# Altium schematic sheet AMX_sensors30.SchDoc(AMX_sensors30)
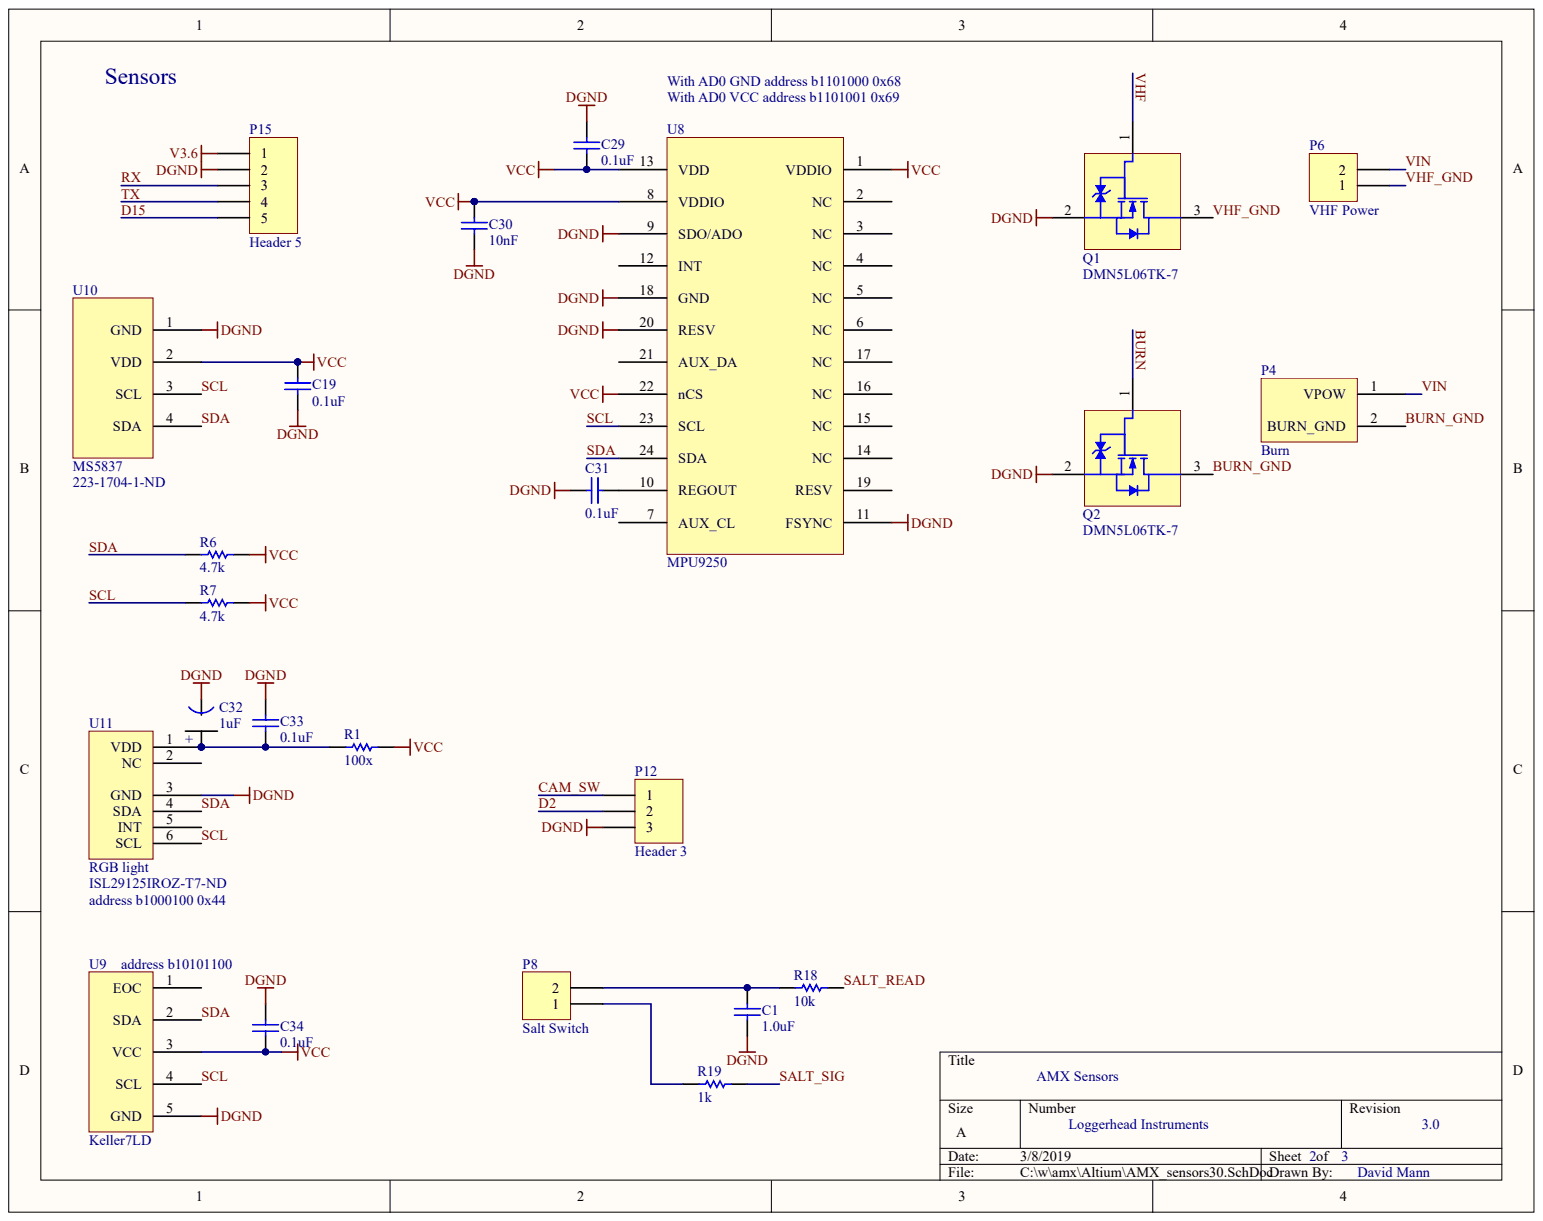
[diagram p0: AMX_sensors30.SchDoc]

## Parts
C1  1.0uF
C19  0.1uF
C29  0.1uF
C30  10nF
C31  0.1uF
C32  1uF
C33  0.1uF
C34  0.1uF
P4  Burn
P6  VHF Power
P8  Salt Switch
P12  Header 3
P15  Header 5
Q1  DMN5L06TK-7
Q2  DMN5L06TK-7
R1  100x
R6  4.7k
R7  4.7k
R18  10k
R19  1k
U8  MPU9250
U9  Keller7LD
U10  MS5837  Supplier Part Number 1: 223-1704-1-ND
U11  RGB light  Supplier Part Number 1: ISL29125IROZ-T7-ND

## Nets
DGND: C1.2 C19.1 C29.2 C30.2 C31.1 C32.1 C33.2 C34.2 P12.3 P15.2 Q1.2 Q2.2 U8.9(SDO/ADO) U8.11(FSYNC) U8.18(GND) U8.20(RESV) U9.5(GND) U10.1(GND) U11.3(GND)
V3.6: P15.1
VCC: C19.2 C29.1 C30.1 C34.1 R1.1 R6.1 R7.2 U8.1(VDDIO) U8.8(VDDIO) U8.13(VDD) U8.22(nCS) U9.3(VCC) U10.2(VDD)
BURN: Q2.1
BURN_GND: P4.2 Q2.3
CAM_SW: P12.1
D2: P12.2
D15: P15.5
RX: P15.3
SALT_READ: R18.1
SALT_SIG: R19.2
SCL: R7.1 U8.23(SCL) U9.4(SCL) U10.3(SCL) U11.6(SCL)
SDA: R6.2 U8.24(SDA) U9.2(SDA) U10.4(SDA) U11.4(SDA)
TX: P15.4
VHF: Q1.1
VHF_GND: P6.1 Q1.3
VIN: P4.1 P6.2
NetC1_1: C1.1 P8.2 R18.2
NetC31_2: C31.2 U8.10(REGOUT)
NetC32_2: C32.2 C33.1 R1.2 U11.1(VDD)
NetP8_1: P8.1 R19.1

Not connected: U8 2(NC) 3(NC) 4(NC) 5(NC) 6(NC) 7(AUX_CL) 12(INT) 14(NC) 15(NC) 16(NC) 17(NC) 19(RESV) 21(AUX_DA); U9 1(EOC); U11 2(NC) 5(INT)

## Library data of the parts
C1 = (no symbol name): Note: Footprint corresponds to dimensions for bulk cassette packaging. This case size has tightened tolerances.; Value: 0.1uF; Published: 17-Jul-2002; LatestRevisionDate: 19-Mar-2004; LatestRevisionNote: Silkscreen size reduced.; DatasheetDocument: Mar-2001; PackageDocument: Mar-2001; PackageReference: 0402; Code_JEITA: 0402; Code_IPC: 1005 [0402]; ComponentLink1Description: Manufacturer Link; ComponentLink1URL: http://www.kemet.com/; ComponentLink2Description: Datasheet; ComponentLink2URL: http://www.kemet.com/kemet/web/homepage/kechome.nsf/vapubfilesname/F3102TA.pdf/$file/F3102TA.pdf; Publisher: Altium Limited
C19 C29-C31 C33 C34 = (no symbol name): Note: Footprint corresponds to dimensions for bulk cassette packaging. This case size has tightened tolerances.; Published: 17-Jul-2002; LatestRevisionDate: 19-Mar-2004; LatestRevisionNote: Silkscreen size reduced.; DatasheetDocument: Mar-2001; PackageDocument: Mar-2001; PackageReference: 0402; Code_JEITA: 0402; Code_IPC: 1005 [0402]; ComponentLink1Description: Manufacturer Link; ComponentLink1URL: http://www.kemet.com/; ComponentLink2Description: Datasheet; ComponentLink2URL: http://www.kemet.com/kemet/web/homepage/kechome.nsf/vapubfilesname/F3102TA.pdf/$file/F3102TA.pdf; Publisher: Altium Limited
  C19 C29 C31 C33 C34: Value: 0.1uF
  C30: Value: 10nF
Q1 Q2 = (no symbol name): Fabrication Tested: NO; Supplier 1: Digi-Key; Supplier Part Number 1: DMN5L06TKDICT-ND; HelpURL: http://www.diodes.com/datasheets/ds30926.pdf; Creator and Date: Will Flanery 2010-09-02; Footprint Verified By: *; Schematic Verified By: *; Vendor Info Verified By: *
C32 P4 P6 P8 P12 P15 R1 R6 R7 R18 R19 U8-U11 = (no symbol name)
  C32: Value: 1uF
  P6 P8 P12 P15: LatestRevisionDate: 17-Jul-2002; LatestRevisionNote: Re-released for DXP Platform.
  R1 R6 R7 R18 R19: Published: 17-Dec-2003; LatestRevisionDate: 19-Mar-2004; LatestRevisionNote: Silkscreen size reduced.; PackageDocument: Mar-2003; PackageReference: 3-0603; Code_IPC: 1608 [0603]; ComponentLink1Description: Manufacturer Link; ComponentLink1URL: http://www.panasonic.com/industrial/components/resistive_products.htm; ComponentLink2Description: Datasheet; ComponentLink2URL: http://www.panasonic.com/industrial/components/pdf/AOA0000CE2.pdf
  U9: PDF: http://www.kelleramerica.com/pdf-library/Piezoresistive%20OEM%20Pressure%20Transmitters%20with%20I2C%20interface%20and%20embedded%20signal%20conditioning.pdf
  U10 U11: Supplier 1: Digi-Key
  P6 P8 P12 P15 R1 R6 R7 R18 R19: Publisher: Altium Limited
